# Altium schematic sheet Sheet6.SchDoc(Sheet6)
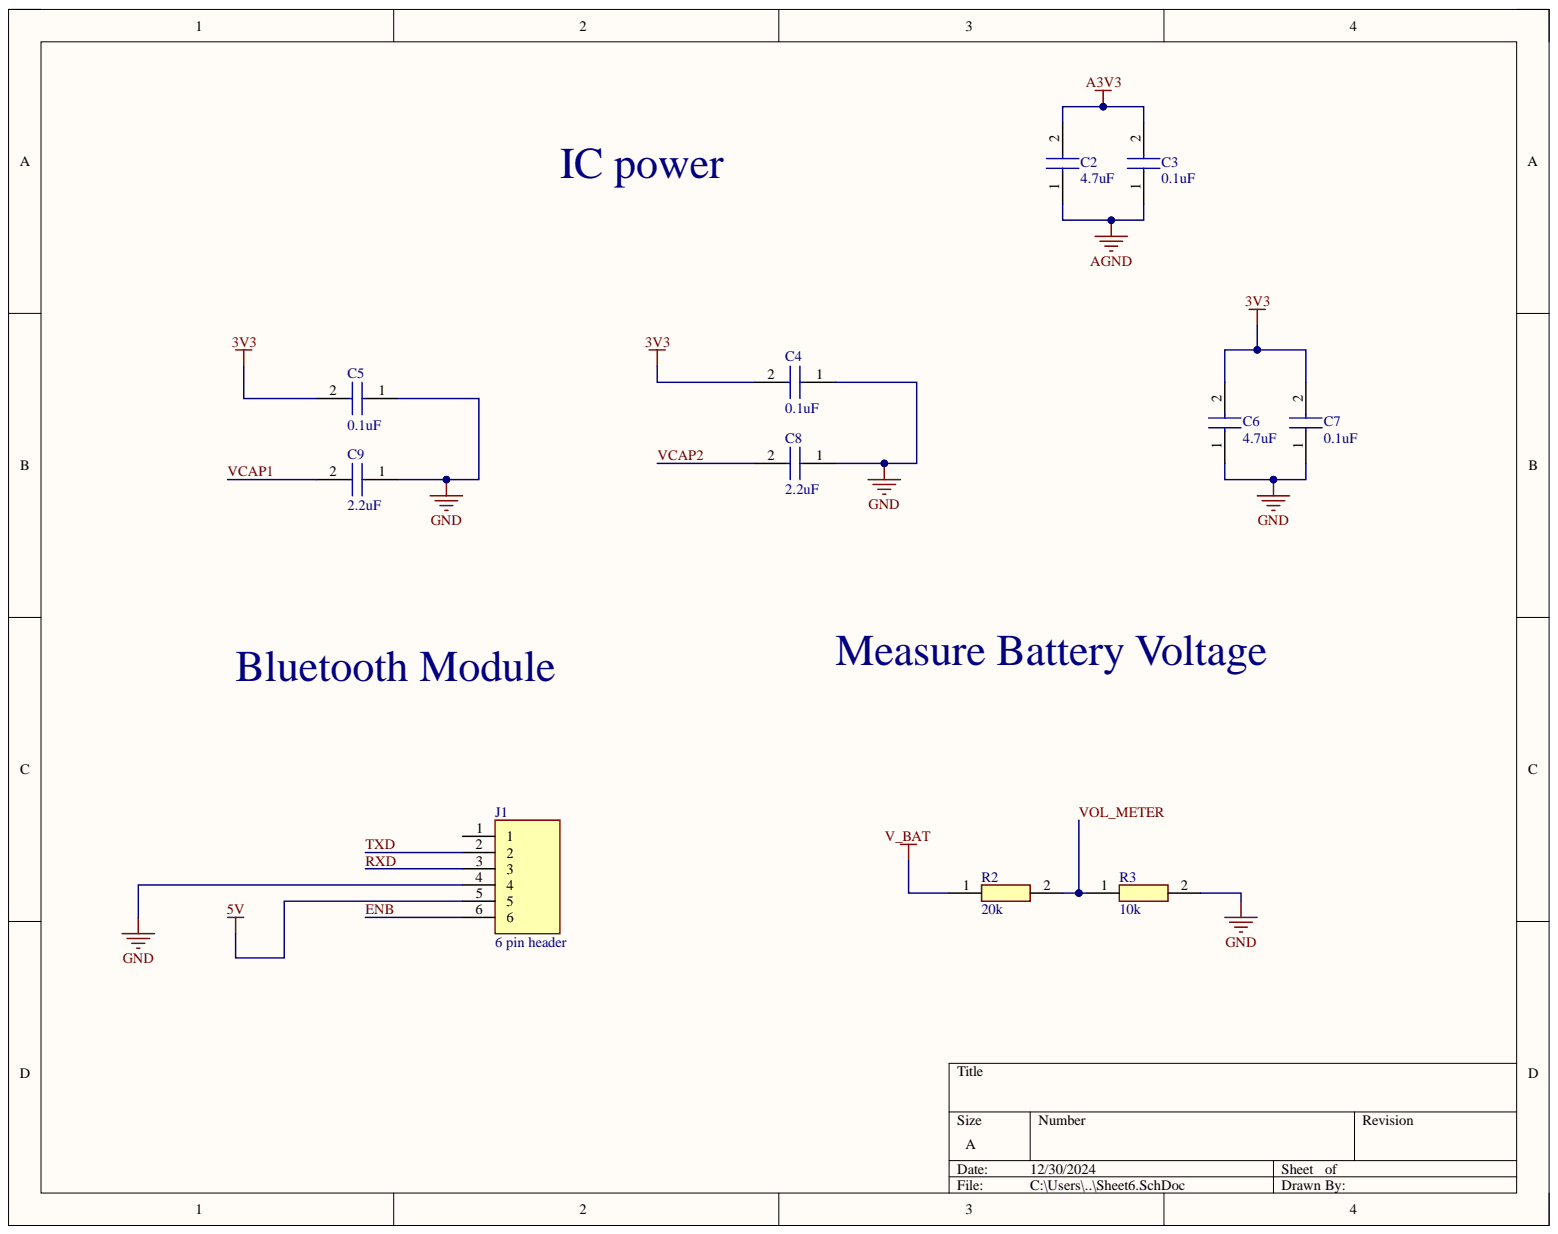
[diagram p0: Sheet6.SchDoc]

## Parts
C2  4.7uF  [CAPC2012X145N]
C3  0.1uF  [CAPC1005X55N]
C4  0.1uF  [CAPC1005X55N]
C5  0.1uF  [CAPC1005X55N]
C6  4.7uF  [CAPC2012X145N]
C7  0.1uF  [CAPC1005X55N]
C8  2.2uF  [CAPC2012X152N]
C9  2.2uF  [CAPC2012X152N]
J1  6 pin header  [RHDR6W76P0X254_1X6_1524X254X826P]
R2  20k  [ERA6AEB101V]
R3  10k  [RESC1608X55N]

## Nets
3V3: C4.2 C5.2 C6.2 C7.2
5V: J1.5
A3V3: C2.2 C3.2
AGND: C2.1 C3.1
GND: C4.1 C5.1 C6.1 C7.1 C8.1 C9.1 J1.4 R3.2
V_BAT: R2.1
ENB: J1.6
RXD: J1.3
TXD: J1.2
VCAP1: C9.2
VCAP2: C8.2
VOL_METER: R2.2 R3.1

Not connected: J1 1

## Library data of the parts
C2 C6 = 4.7uf 25v 10% SMD CAP: Capacitor; Manufacturer_Name: TDK; Manufacturer_Part_Number: CGA4J1X7R1E475K125AE; Arrow Part Number: CGA4J1X7R1E475K125AE; Arrow Price/Stock: https://www.arrow.com/en/products/cga4j1x7r1e475k125ae/tdk?region=nac; Component Kind: Standard; Datasheet Link: http://docs-europe.electrocomponents.com/webdocs/14e9/0900766b814e9125.pdf; Height: 1.45mm; Library Name: Schlib1.SchLib; Mouser Part Number: 810-CGA4J1X7R1E475KA; Mouser Price/Stock: https://www.mouser.co.uk/ProductDetail/TDK/CGA4J1X7R1E475K125AE?qs=nQSIdc08i%252BfhTtI2X7XptA%3D%3D
C3-C5 C7 = 0.1uF: 0.1uf SMD 25V cermaic; Manufacturer_Name: TAIYO YUDEN; Manufacturer_Part_Number: MBAST105SB7104KFNA01; Component Kind: Standard; Datasheet Link: https://ds.yuden.co.jp/TYCOMPAS/or/specSheet?pn=MBAST105SB7104KFNA01; Height: 0.55mm; Library Name: Schlib1.SchLib; Mouser Part Number: 963-BAST105SB7104KF; Mouser Price/Stock: https://www.mouser.co.uk/ProductDetail/TAIYO-YUDEN/MBAST105SB7104KFNA01?qs=tlsG%2FOw5FFj0FpJuqULGiw%3D%3D
C8 C9 = 2.2uF: 2.2uF ceramic SMD cap 10% 25V 2.01mm; Manufacturer_Name: Kyocera AVX; Manufacturer_Part_Number: 08053C225K4Z2A; Component Kind: Standard; Datasheet Link: http://datasheets.avx.com/X7RDielectric.pdf; Height: 1.52mm; Library Name: Schlib1.SchLib; Mouser Part Number: 581-08053C225K4Z2A; Mouser Price/Stock: https://www.mouser.co.uk/ProductDetail/KYOCERA-AVX/08053C225K4Z2A?qs=BZBei1rCqCBERhbZUpSz5Q%3D%3D
J1 = 6 pin header: Connector; Manufacturer_Name: 3M; Manufacturer_Part_Number: 929850-01-06-RA; Arrow Part Number: 929850-01-06-RA; Arrow Price/Stock: https://www.arrow.com/en/products/929850-01-06-ra/3m?region=nac; Component Kind: Standard; Datasheet Link: https://componentsearchengine.com/Datasheets/1/929850-01-06-RA.pdf; Height: 8.26mm; Library Name: Schlib1.SchLib; Mouser Part Number: 517-929850-01-06-RA; Mouser Price/Stock: https://www.mouser.co.uk/ProductDetail/3M-Electronic-Solutions-Division/929850-01-06-RA?qs=neFkstNq%252B6FIVDxcl2uoCg%3D%3D
R2 = 20k: Thin Film Resistors - SMD 0805 20Kohms 25ppm 0.5% AEC-Q200; Manufacturer_Name: Panasonic; Manufacturer_Part_Number: ERA-6AED203V; Component Kind: Standard; Datasheet Link: https://industrial.panasonic.com/cdbs/www-data/pdf/RDM0000/AOA0000C307.pdf; Height: 0.6mm; Library Name: Schlib1.SchLib; Mouser Part Number: 667-ERA-6AED203V; Mouser Price/Stock: https://www.mouser.co.uk/ProductDetail/Panasonic/ERA-6AED203V?qs=yocZuyCaXdPQLdQbF9BAQg%3D%3D
R3 = 10k: Thin Film Resistors - SMD MCT 0603-25 0.1% AT P5 10K5; Manufacturer_Name: Vishay; Manufacturer_Part_Number: MCT0603MD1052BP500; Component Kind: Standard; Datasheet Link: http://www.vishay.com/docs/28705/mcx0x0xpro.pdf; Height: 0.55mm; Library Name: Schlib1.SchLib; Mouser Part Number: 594-MCT0603MD1052BP5; Mouser Price/Stock: https://www.mouser.co.uk/ProductDetail/Vishay-Beyschlag/MCT0603MD1052BP500?qs=17u8i%2FzlE883pCG3RQV5%2Fw%3D%3D
